# Altium schematic sheet SAW_RFID_Sheet1.SchDoc
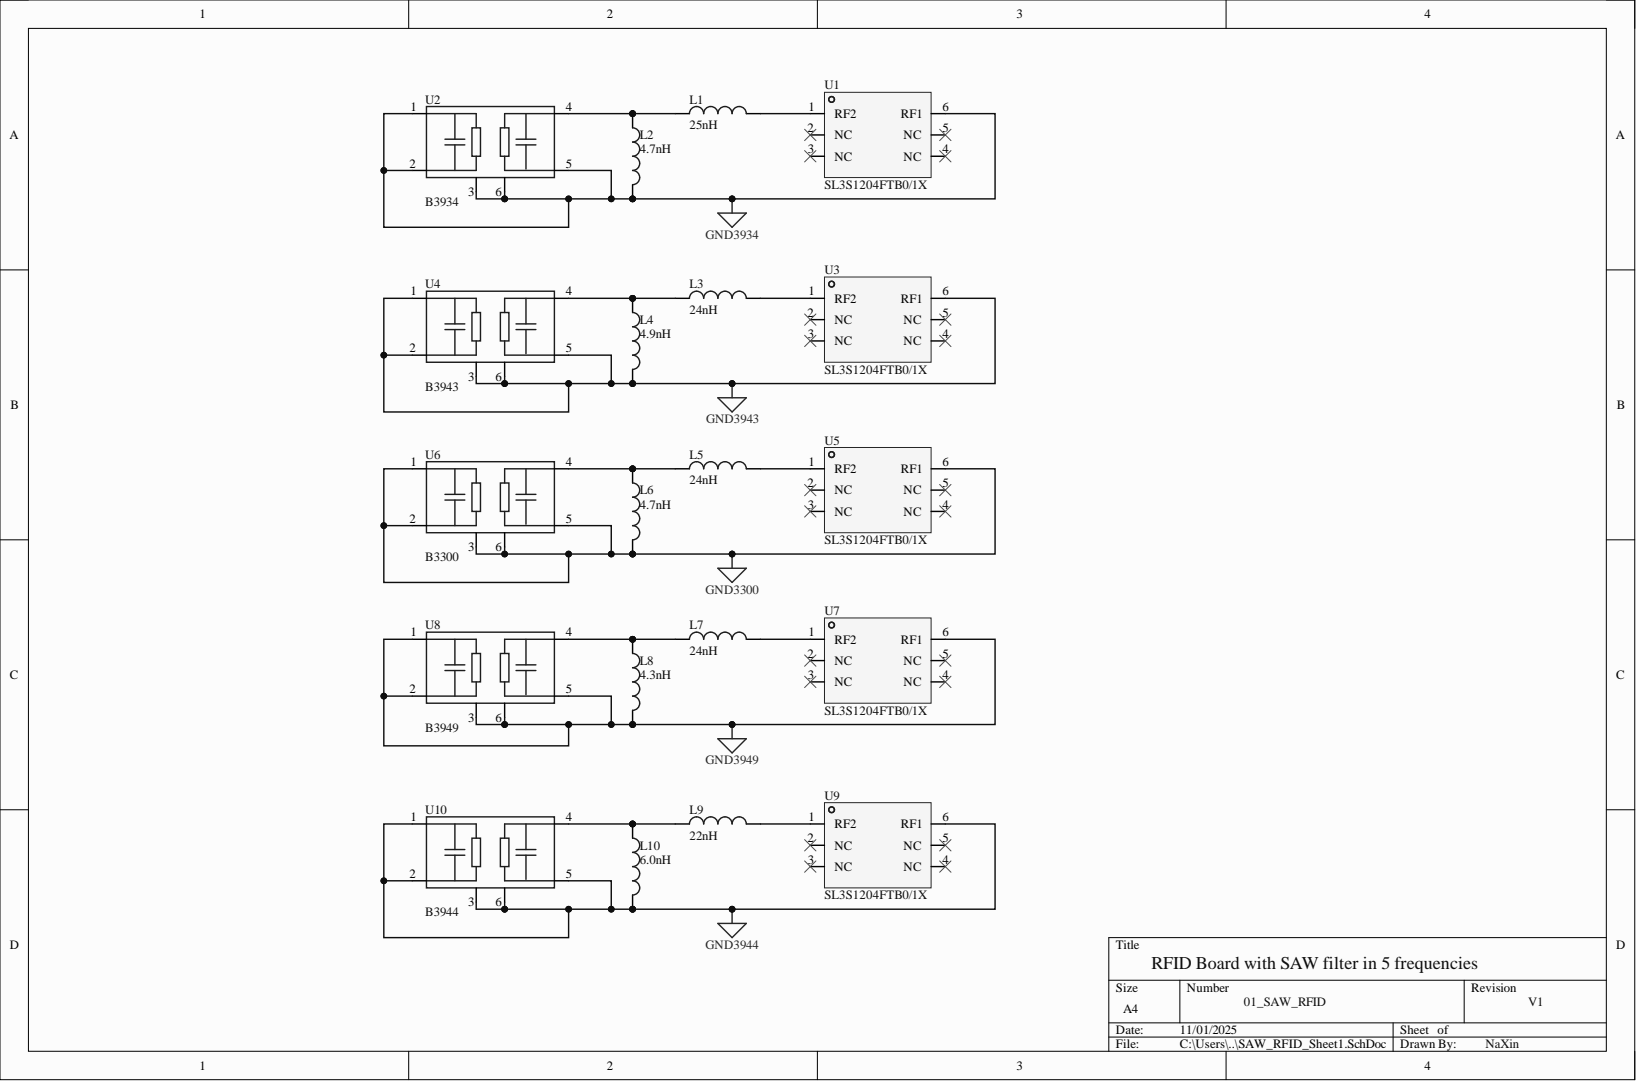
[diagram p0: SAW_RFID_Sheet1.SchDoc]

## Parts
L1  25nH  [0603L]
L2  4.7nH  [0603L]
L3  24nH  [0603L]
L4  4.9nH  [0603L]
L5  24nH  [0603L]
L6  4.7nH  [0603L]
L7  24nH  [0603L]
L8  4.3nH  [0603L]
L9  22nH  [0603L]
L10  6.0nH  [0603L]
U1  SL3S1204FTB0/1X  [XSON6]
U2  B3934  [DCC6E]
U3  SL3S1204FTB0/1X  [XSON6]
U4  B3943  [DCC6E]
U5  SL3S1204FTB0/1X  [XSON6]
U6  B3300  [DCC6E]
U7  SL3S1204FTB0/1X  [XSON6]
U8  B3949  [DCC6E]
U9  SL3S1204FTB0/1X  [XSON6]
U10  B3944  [DCC6E]

## Nets
GND3300: L6.2 U5.6(RF1) U6.1 U6.2 U6.3 U6.5 U6.6
GND3934: L2.2 U1.6(RF1) U2.1 U2.2 U2.3 U2.5 U2.6
GND3943: L4.2 U3.6(RF1) U4.1 U4.2 U4.3 U4.5 U4.6
GND3944: L10.2 U9.6(RF1) U10.1 U10.2 U10.3 U10.5 U10.6
GND3949: L8.2 U7.6(RF1) U8.1 U8.2 U8.3 U8.5 U8.6
NetL1_1: L1.1 L2.1 U2.4
NetL1_2: L1.2 U1.1(RF2)
NetL3_1: L3.1 L4.1 U4.4
NetL3_2: L3.2 U3.1(RF2)
NetL5_1: L5.1 L6.1 U6.4
NetL5_2: L5.2 U5.1(RF2)
NetL7_1: L7.1 L8.1 U8.4
NetL7_2: L7.2 U7.1(RF2)
NetL9_1: L9.1 L10.1 U10.4
NetL9_2: L9.2 U9.1(RF2)

Not connected: U1 2(NC) 3(NC) 4(NC) 5(NC); U3 2(NC) 3(NC) 4(NC) 5(NC); U5 2(NC) 3(NC) 4(NC) 5(NC); U7 2(NC) 3(NC) 4(NC) 5(NC); U9 2(NC) 3(NC) 4(NC) 5(NC)

## Library data of the parts
L1-L10 = Inductor: Component Kind: Standard; Library Name: SAW_RFID.SchLib
U1 U3 U5 U7 U9 = SL3S1204FTB0/1X: C3304647; Component Kind: Standard; Library Name: SAW_RFID.SchLib
U2 = B39901B3934H110: C6467284; Component Kind: Standard; Library Name: SAW_RFID.SchLib
U4 = B39911B3943H110: C6467286; Component Kind: Standard; Library Name: SAW_RFID.SchLib
U6 = B39921B3300H110: C5571565; Component Kind: Standard; Library Name: SAW_RFID.SchLib
U8 = B39921B3949H110: C6863780; Component Kind: Standard; Library Name: SAW_RFID.SchLib
U10 = B39931B3944H110: C5593998; Component Kind: Standard; Library Name: SAW_RFID.SchLib
